# Altium schematic sheet WiFi.SchDoc(U_WiFi)
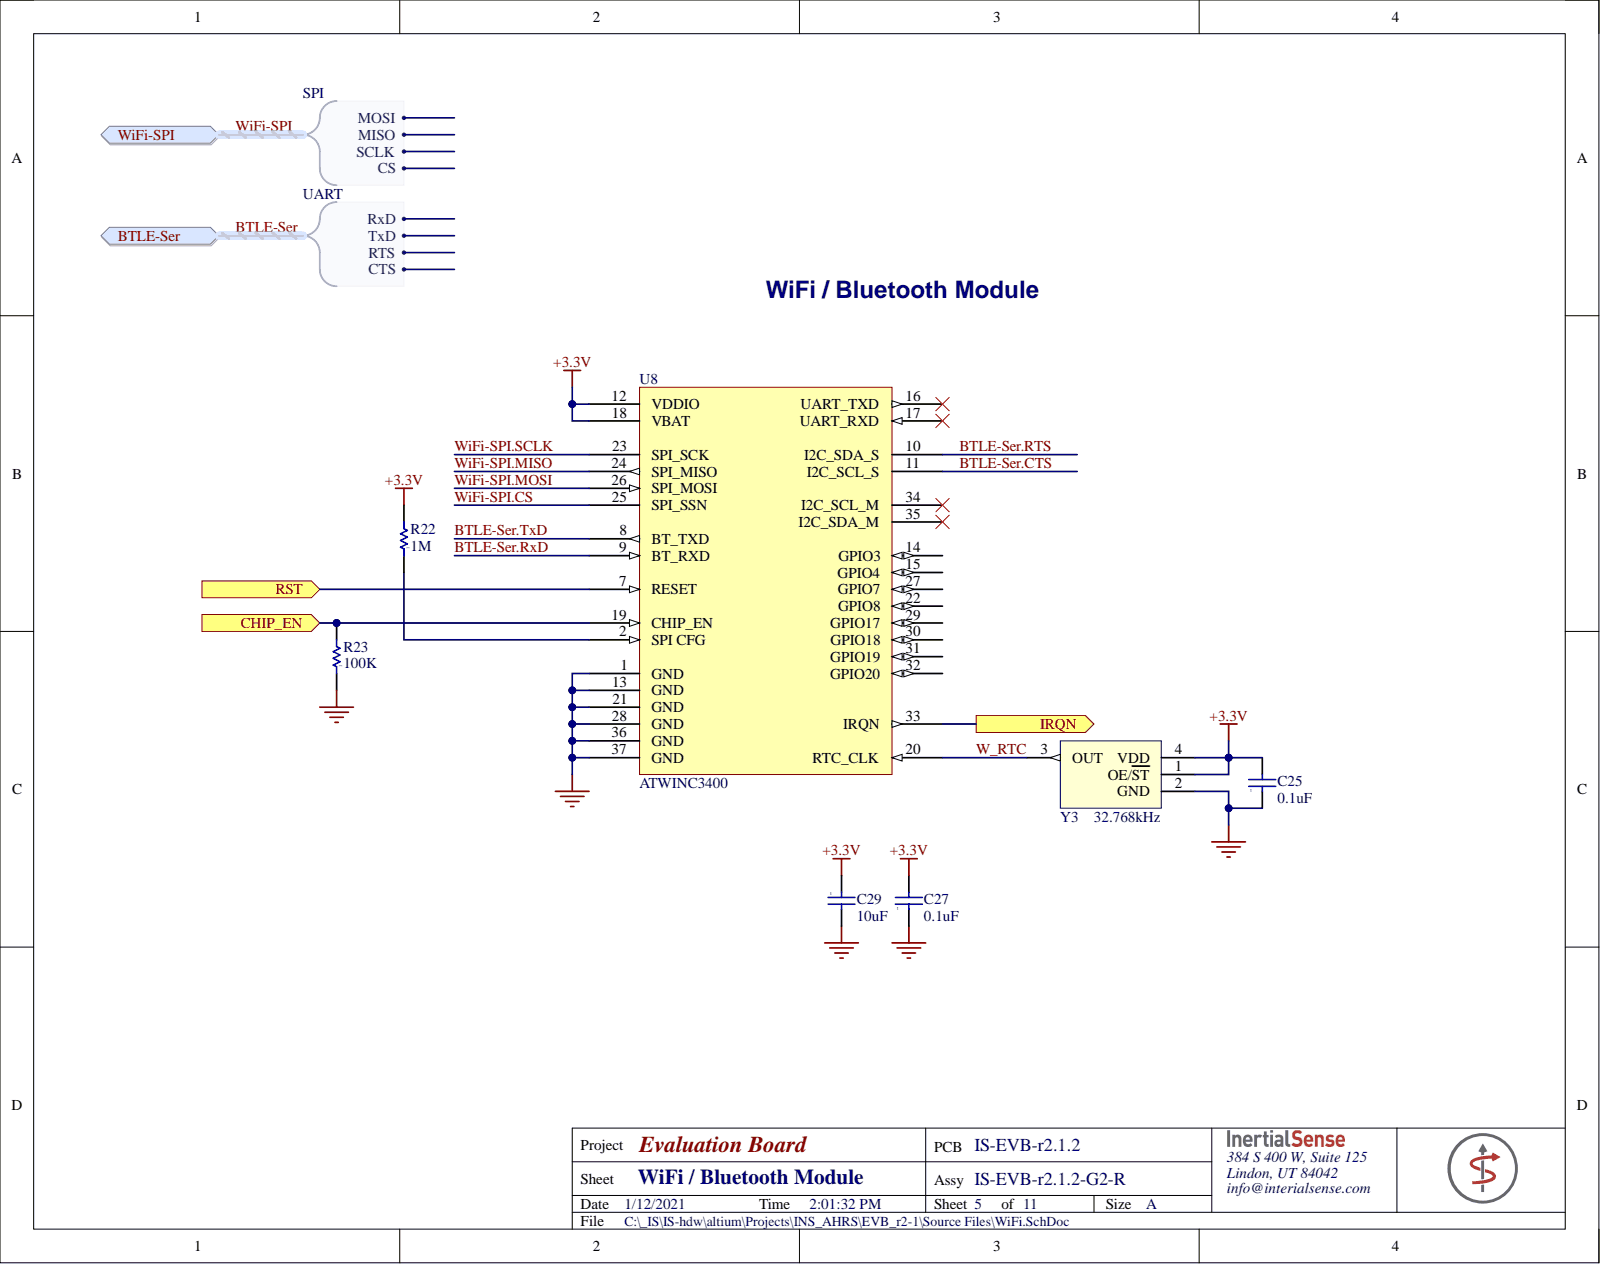
[diagram p0: WiFi.SchDoc]

## Parts
C25  value 0.1uF  [0402]  (hidden comment: Cap)
C27  value 0.1uF  [0402]  (hidden comment: Cap)
C29  value 10uF  Capacitance: 10uF  [0805]  (hidden comment: Cap)
R22  value 1M  [R0402]  (hidden comment: Res)
R23  value 100K  [R0402]  (hidden comment: Res)
U8  ATWINC3400  [ATWILC3000]
Y3  Frequency: 32.768kHz  [SiT1533]  (hidden comment: Oscillator2)

## Ports
BTLE-Ser, BTLE-Ser.CTS, BTLE-Ser.RTS, BTLE-Ser.RxD, BTLE-Ser.TxD, CHIP_EN, IRQN, WiFi-SPI, WiFi-SPI.CS, WiFi-SPI.MISO, WiFi-SPI.MOSI, WiFi-SPI.SCLK, ~{RST}

## Nets
+3.3V: C25.2 C27.2 C29.1 R22.2 U8.12(VDDIO) U8.18(VBAT) Y3.1(OE/ST) Y3.4(VDD)
GND: C25.1 C27.1 C29.2 R23.1 U8.1(GND) U8.13(GND) U8.21(GND) U8.28(GND) U8.36(GND) U8.37(GND) Y3.2(GND)
~{RST}: U8.7(RESET) ; port ~{RST}
BTLE-Ser.CTS: U8.11(I2C_SCL_S) ; port BTLE-Ser
BTLE-Ser.RTS: U8.10(I2C_SDA_S) ; port BTLE-Ser
BTLE-Ser.RxD: U8.9(BT_RXD) ; port BTLE-Ser
BTLE-Ser.TxD: U8.8(BT_TXD) ; port BTLE-Ser
CHIP_EN: R23.2 U8.19(CHIP_EN) ; port CHIP_EN
IRQN: U8.33(IRQN) ; port IRQN
W_RTC: U8.20(RTC_CLK) Y3.3(OUT)
WiFi-SPI.CS: U8.25(SPI_SSN) ; port WiFi-SPI
WiFi-SPI.MISO: U8.24(SPI_MISO) ; port WiFi-SPI
WiFi-SPI.MOSI: U8.26(SPI_MOSI) ; port WiFi-SPI
WiFi-SPI.SCLK: U8.23(SPI_SCK) ; port WiFi-SPI
NetR22_1: R22.1 U8.2(SPI_CFG)
NetU8_14: U8.14(GPIO3)
NetU8_15: U8.15(GPIO4)
NetU8_16: U8.16(UART_TXD)
NetU8_17: U8.17(UART_RXD)
NetU8_22: U8.22(GPIO8)
NetU8_27: U8.27(GPIO7)
NetU8_29: U8.29(GPIO17)
NetU8_30: U8.30(GPIO18)
NetU8_31: U8.31(GPIO19)
NetU8_32: U8.32(GPIO20)
NetU8_34: U8.34(I2C_SCL_M)
NetU8_35: U8.35(I2C_SDA_M)

## Library data of the parts
C25 C27 = Cap: CAP CER .10UF 50V X7R 10% 0402; Manufacturer: TDK Corporation; Manufacturer Part Number: C1005X7R1H104K; Capacitance: 0.1µF; Applications: General Purpose; Category: Capacitors; Component Kind: Standard; ComponentLink1Description: http://www.tdk.co.jp/tefe02/e412_c.pdf; ComponentLink1URL: http://www.tdk.co.jp/tefe02/e412_c.pdf; Features: -; Height: -; LatestRevisionDate: 17-Jul-2002; LatestRevisionNote: Re-released for DXP Platform.; Lead Spacing: -; Lead Style: -; Library Name: General.SchLib; Mounting Type: Surface Mount, MLCC; Operating Temperature: -55°C ~ 125°C; Package / Case: 0402 (1005 Metric); Packaging: Cut Tape (CT); Pricing 1: 1=0.3, 10=0.2, 100=0.08, 250=0.05752, 500=0.041, 1000=0.03 (USD); Published: 8-Jun-2000; Ratings: -; RoHS: RoHS Compliant; Signal Integrity: Cap; Simulation: CAP; Size / Dimension: 0.039" L x 0.020" W (1.00mm x 0.50mm); Stock 1: 174080; Supplier 1: Digi-Key; Supplier Part Number 1: 445-5932-1-ND; Temperature Coefficient: X7R; Thickness: 0.022" (0.55mm); Tolerance: ±10%; Voltage - Rated: 50V; Publisher: Altium Limited
C29 = Cap: CAP CER 10UF 35V X5R 0805; Manufacturer: TDK; Manufacturer Part Number: C2012X5R1V106K085AC; Dielectric Material: Ceramic; Tolerance: 10%; Case Code (Imperial): 0805; Case Code (Metric): 2012; Case/Package: 0805; Category: Capacitors; Component Kind: Standard; ComponentLink1Description: https://datasheet.ciiva.com/14894/tdkmlcccaprange-14894527.pdf; ComponentLink1URL: https://datasheet.ciiva.com/14894/tdkmlcccaprange-14894527.pdf; Dielectric: X5R; Height: 0.85mm; LatestRevisionDate: 17-Jul-2002; LatestRevisionNote: Re-released for DXP Platform.; Lead Free: Lead Free; Length: 0.079inch; Library Name: General.SchLib; Max Operating Temperature: 85°C; Min Operating Temperature: -55°C; Mount: Surface Mount; Package Quantity: 1; Packaging: Tape and Reel; Pricing 1: 1=0.83, 10=0.589, 100=0.3881, 500=0.2875, 1000=0.24438 (USD); Published: 8-Jun-2000; REACH SVHC: No SVHC; Signal Integrity: Cap; Simulation: CAP; Stock 1: 0; Supplier 1: Digi-Key; Supplier Part Number 1: 445-14417-6-ND; Termination: SMD/SMT; Thickness: 0.039inch; Voltage Rating: 35V; Voltage Rating (DC): 35V; Weight: 0.000194oz; Width: 0.049inch; Publisher: Altium Limited
R22 = Res: RES SMD 1M OHM 5% 1/10W 0402; Manufacturer: Panasonic; Manufacturer Part Number: ERJ-2GEJ105X; Max Power Dissipation: 100mW; Resistance: 1MR; Temperature Coefficient: 200ppm/°C; Voltage Rating: 50V; Case Code (Imperial): 0402; Case Code (Metric): 1005; Case/Package: 0402; Component Kind: Standard; Composition: Thick Film; Contact Plating: Tin; Depth: 0.5mm; Features: Automotive AEC-Q200; Height: 0.35mm; Lead Free: Lead Free; Length: 1mm; Library Name: General.SchLib; Max Operating Temperature: 155°C; Military Standard: Not; Min Operating Temperature: -55°C; Mount: Surface Mount; Number of Terminations: 2; Package Quantity: 1; Packaging: Tape and Reel; Power Rating: 100mW; Pricing 1: 1=0.1, 10=0.031, 100=0.0125, 1000=0.0056, 2500=0.00486, 5000=0.00401 (USD); Radiation Hardening: No; REACH SVHC: No SVHC; Signal Integrity: Res; Simulation: RES; Stock 1: 678090; Supplier 1: Digi-Key; Supplier Part Number 1: P1.0MJDKR-ND; Termination: SMD/SMT; Tolerance: 5%; Voltage Rating (DC): 50V; Width: 0.5mm
R23 = Res: RES SMD 100K OHM 1% 1/16W 0402; Manufacturer: Panasonic; Manufacturer Part Number: ERJ-2RKF1003X; Max Power Dissipation: 100mW; Resistance: 100kR; Temperature Coefficient: 100ppm/°C; Voltage Rating: 50V; Case Code (Imperial): 0402; Case Code (Metric): 1005; Case/Package: 0402; Component Kind: Standard; Composition: Thick Film; Contact Plating: Tin; Depth: 0.5mm; Features: Automotive AEC-Q200; Height: 0.35mm; Lead Free: Lead Free; Length: 1mm; Library Name: General.SchLib; Max Operating Temperature: 155°C; Military Standard: Not; Min Operating Temperature: -55°C; Mount: Surface Mount; Number of Pins: 2; Number of Terminations: 2; Package Quantity: 1; Packaging: Tape and Reel; Power Rating: 100mW; Pricing 1: 1=0.1, 10=0.045, 100=0.0184, 1000=0.00826, 2500=0.00717, 5000=0.00593 (USD); Radiation Hardening: No; REACH SVHC: No SVHC; Signal Integrity: Res; Simulation: RES; Stock 1: 6572; Supplier 1: Digi-Key; Supplier Part Number 1: P100KLDKR-ND; Termination: SMD/SMT; Tolerance: 1%; Voltage Rating (DC): 50V; Width: 0.5mm
U8 = ATWINC3400: WIFI/BLE MODULE; Manufacturer: Microchip; Manufacturer Part Number: ATWINC3400-MR210UA122; Component Kind: Standard; Library Name: General.SchLib; Stock 1: 0; Supplier 1: Digi-Key; Supplier Part Number 1: ATWINC3400-MR210UA122-ND
Y3 = Oscillator2: OSC MEMS 32.768KHZ LVCMOS SMD; Manufacturer: SiTime; Manufacturer Part Number: SIT1533AI-H4-DCC-32.768E; Current Rating: 1.35uA; Frequency Stability: 100ppm; Load Capacitance: 10pF; Max Duty Cycle: 52%; Max Supply Current: 1.4uA; Max Supply Voltage: 3.63V; Min Supply Voltage: 1.5V; Case/Package: SMD/SMT; Component Kind: Standard; Height: 0.024inch; Length: 0.079inch; Library Name: General.SchLib; Max Operating Temperature: 85°C; Min Operating Temperature: -40°C; Mount: Surface Mount; Number of Pins: 4; Package Quantity: 1000; Packaging: Tape and Reel; Pricing 1: 1=1.21, 10=1.072, 50=1.0018, 100=0.8852, 500=0.83866 (USD); Pricing 2: 1=1.21, 10=1.072, 50=1.0018, 100=0.8852, 500=0.83866 (USD); Stock 1: 127563; Stock 2: 135399; Supplier 1: Digi-Key; Supplier 2: Digi-Key; Supplier Part Number 1: 1473-1239-1-ND; Supplier Part Number 2: 1473-1239-6-ND; Termination: SMD/SMT; VALUE: ?; Width: 0.047inch
